# Altium schematic sheet driver.SchDoc
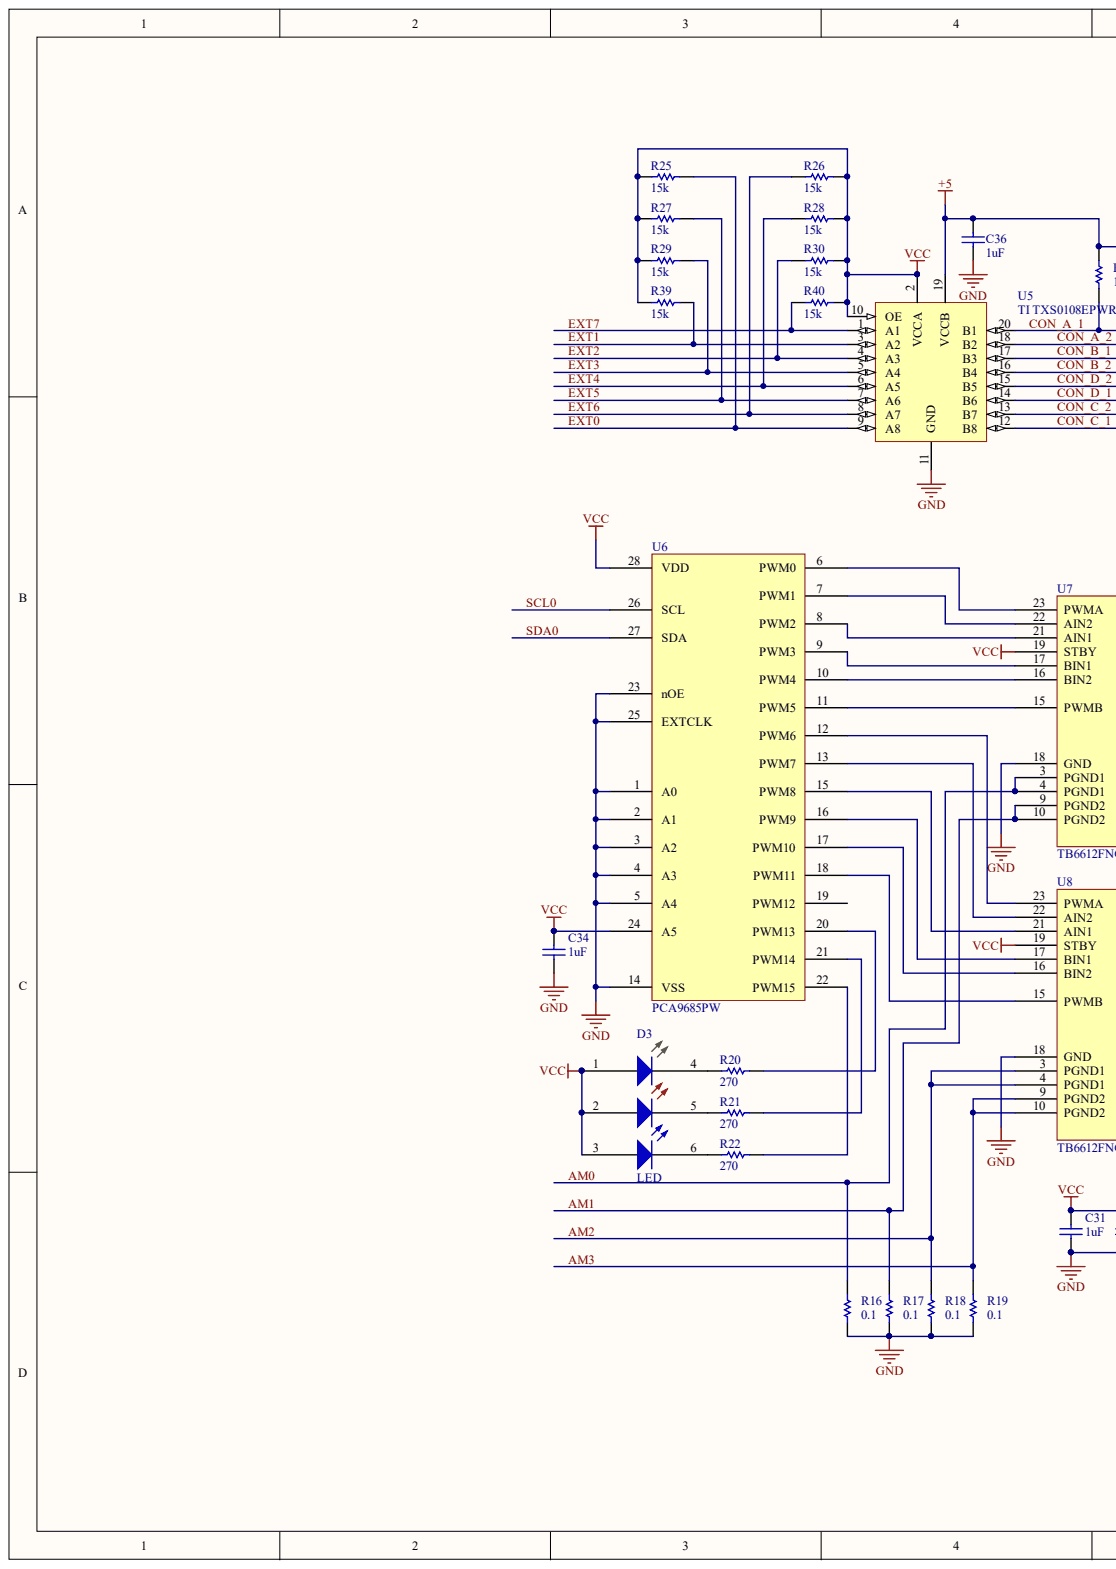
[diagram p0: driver.SchDoc, left]
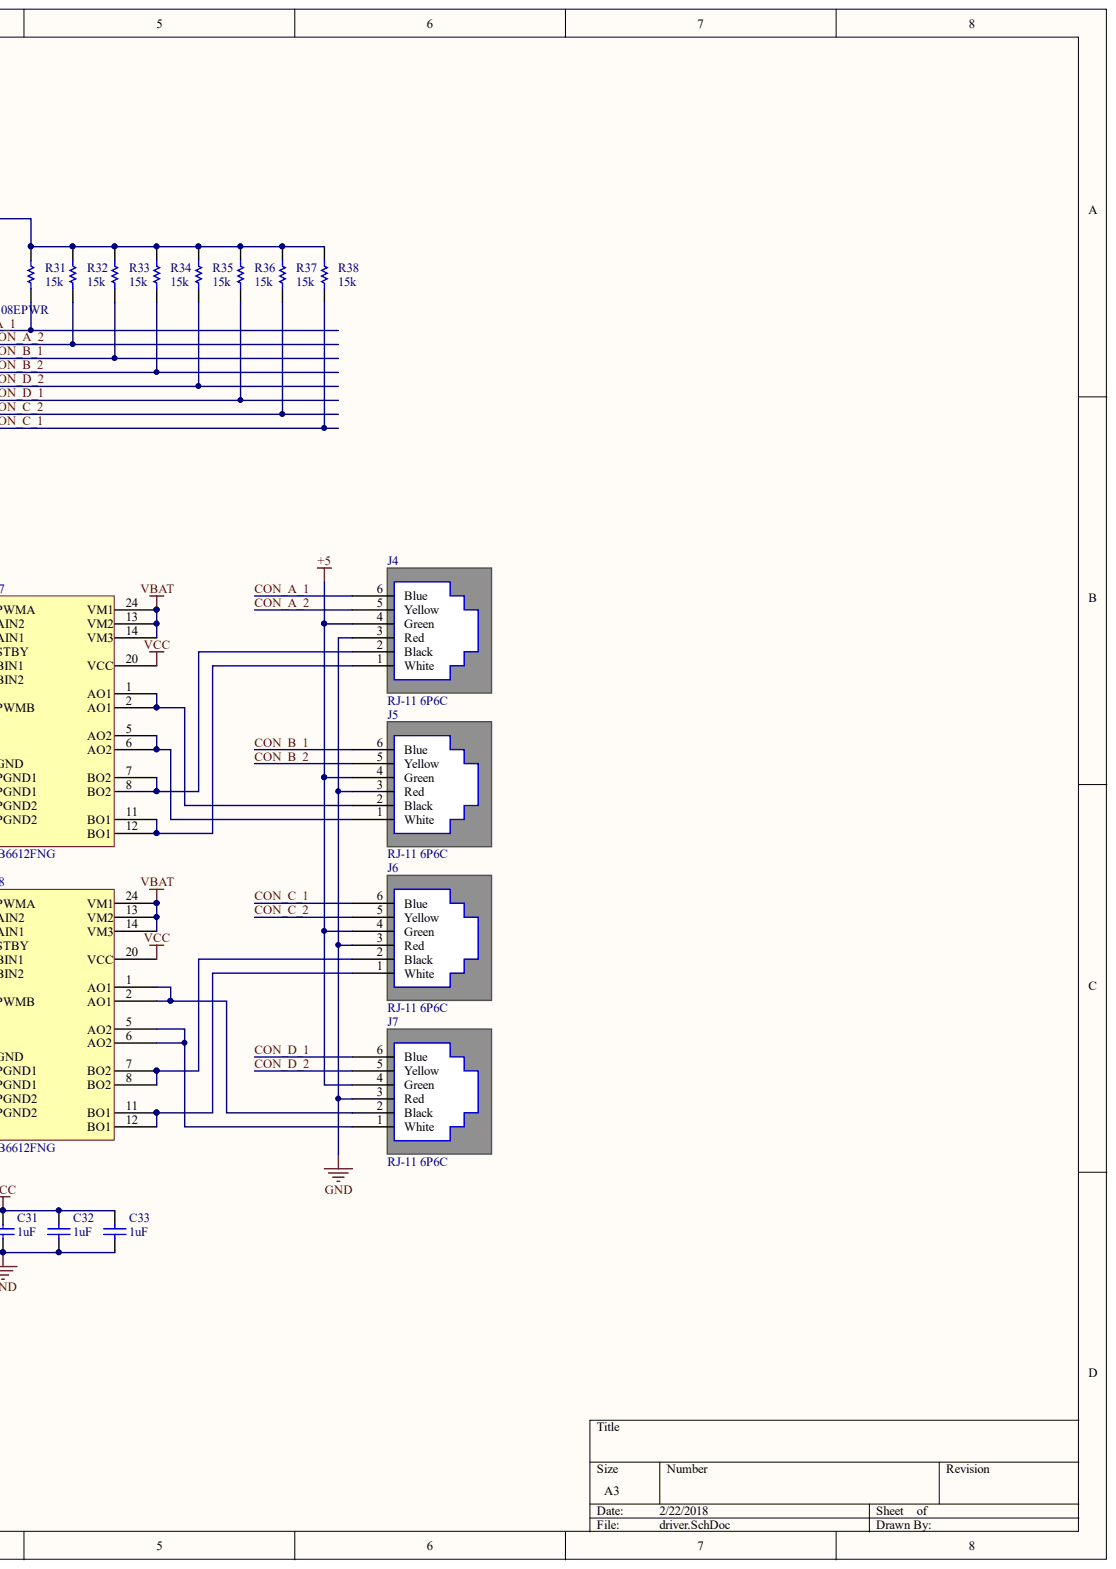
[diagram p1: driver.SchDoc, right]

## Parts
C31  Capacitance: 1uF  [CAPC1608X90X35LL15T15]  (hidden comment: AVX Interconnect / Elco 06036D105KAT2A)
C32  Capacitance: 1uF  [CAPC1608X90X35LL15T15]  (hidden comment: AVX Interconnect / Elco 06036D105KAT2A)
C33  Capacitance: 1uF  [CAPC1608X90X35LL15T15]  (hidden comment: AVX Interconnect / Elco 06036D105KAT2A)
C34  Capacitance: 1uF  [CAPC1608X90X35LL15T15]  (hidden comment: AVX Interconnect / Elco 06036D105KAT2A)
C36  Capacitance: 1uF  [CAPC1608X90X35LL15T15]  (hidden comment: AVX Interconnect / Elco 06036D105KAT2A)
D3  LED  [PCB-b482zloe7qu4nyfcg9uy-1]
J4  RJ-11 6P6C  [PCB-dhj0y0hoiu0232y63q4o-1]
J5  RJ-11 6P6C  [PCB-dhj0y0hoiu0232y63q4o-1]
J6  RJ-11 6P6C  [PCB-dhj0y0hoiu0232y63q4o-1]
J7  RJ-11 6P6C  [PCB-dhj0y0hoiu0232y63q4o-1]
R16  Resistance: 0.1  [PCB-7w67gjgbmz27625n7m9y-1]  (hidden comment: Panasonic ERJ-S6SFR10V)
R17  Resistance: 0.1  [PCB-7w67gjgbmz27625n7m9y-1]  (hidden comment: Panasonic ERJ-S6SFR10V)
R18  Resistance: 0.1  [PCB-7w67gjgbmz27625n7m9y-1]  (hidden comment: Panasonic ERJ-S6SFR10V)
R19  Resistance: 0.1  [PCB-7w67gjgbmz27625n7m9y-1]  (hidden comment: Panasonic ERJ-S6SFR10V)
R20  Vishay Dale CRCW0603200KFKEA  [RESC1609X50X30ML10T20]  (value not drawn)
R21  Vishay Dale CRCW0603200KFKEA  [RESC1609X50X30ML10T20]  (value not drawn)
R22  Vishay Dale CRCW0603200KFKEA  [RESC1609X50X30ML10T20]  (value not drawn)
R25  Resistance: 15k  [RESC1608X55X30NL20T20]  (hidden comment: Panasonic ERA-3AED153V)
R26  Resistance: 15k  [RESC1608X55X30NL20T20]  (hidden comment: Panasonic ERA-3AED153V)
R27  Resistance: 15k  [RESC1608X55X30NL20T20]  (hidden comment: Panasonic ERA-3AED153V)
R28  Resistance: 15k  [RESC1608X55X30NL20T20]  (hidden comment: Panasonic ERA-3AED153V)
R29  Resistance: 15k  [RESC1608X55X30NL20T20]  (hidden comment: Panasonic ERA-3AED153V)
R30  Resistance: 15k  [RESC1608X55X30NL20T20]  (hidden comment: Panasonic ERA-3AED153V)
R31  Resistance: 15k  [RESC1608X55X30NL20T20]  (hidden comment: Panasonic ERA-3AED153V)
R32  Resistance: 15k  [RESC1608X55X30NL20T20]  (hidden comment: Panasonic ERA-3AED153V)
R33  Resistance: 15k  [RESC1608X55X30NL20T20]  (hidden comment: Panasonic ERA-3AED153V)
R34  Resistance: 15k  [RESC1608X55X30NL20T20]  (hidden comment: Panasonic ERA-3AED153V)
R35  Resistance: 15k  [RESC1608X55X30NL20T20]  (hidden comment: Panasonic ERA-3AED153V)
R36  Resistance: 15k  [RESC1608X55X30NL20T20]  (hidden comment: Panasonic ERA-3AED153V)
R37  Resistance: 15k  [RESC1608X55X30NL20T20]  (hidden comment: Panasonic ERA-3AED153V)
R38  Resistance: 15k  [RESC1608X55X30NL20T20]  (hidden comment: Panasonic ERA-3AED153V)
R39  Resistance: 15k  [RESC1608X55X30NL20T20]  (hidden comment: Panasonic ERA-3AED153V)
R40  Resistance: 15k  [RESC1608X55X30NL20T20]  (hidden comment: Panasonic ERA-3AED153V)
U5  TI TXS0108EPWR  Manufacturer Part Number: TXS0108EPWR  [TSSOP20-0.65-6.5X4.4MM]
U6  PCA9685PW  [SOP28]
U7  TB6612FNG  [PCB-j6inoi7yxibl7naj9frb-1]
U8  TB6612FNG  [PCB-j6inoi7yxibl7naj9frb-1]

## Nets
+5: C36.1 J4.4(Green) J5.4(Green) J6.4(Green) J7.4(Green) R31.2 R32.2 R33.2 R34.2 R35.2 R36.2 R37.2 R38.2 U5.19(VCCB)
GND: C31.1 C32.1 C33.1 C34.1 C36.2 J4.3(Red) J5.3(Red) J6.3(Red) J7.3(Red) R16.1 R17.1 R18.1 R19.1 U5.11(GND) U6.1(A0) U6.2(A1) U6.3(A2) U6.4(A3) U6.5(A4) U6.14(VSS) U6.23(nOE) U6.25(EXTCLK) U7.18(GND) U8.18(GND)
VBAT: U7.13(VM2) U7.14(VM3) U7.24(VM1) U8.13(VM2) U8.14(VM3) U8.24(VM1)
VCC: C31.2 C32.2 C33.2 C34.2 D3.1 D3.2 D3.3 R25.2 R26.1 R27.2 R28.1 R29.2 R30.1 R39.2 R40.1 U5.2(VCCA) U5.10(OE) U6.24(A5) U6.28(VDD) U7.19(STBY) U7.20(VCC) U8.19(STBY) U8.20(VCC)
AM0: R16.2 U7.3(PGND1) U7.4(PGND1)
AM1: R17.2 U7.9(PGND2) U7.10(PGND2)
AM2: R18.2 U8.3(PGND1) U8.4(PGND1)
AM3: R19.2 U8.9(PGND2) U8.10(PGND2)
CON_A_1: J4.6(Blue) R31.1 U5.20(B1)
CON_A_2: J4.5(Yellow) R32.1 U5.18(B2)
CON_B_1: J5.6(Blue) R33.1 U5.17(B3)
CON_B_2: J5.5(Yellow) R34.1 U5.16(B4)
CON_C_1: J6.6(Blue) R38.1 U5.12(B8)
CON_C_2: J6.5(Yellow) R37.1 U5.13(B7)
CON_D_1: J7.6(Blue) R36.1 U5.14(B6)
CON_D_2: J7.5(Yellow) R35.1 U5.15(B5)
EXT0: R25.1 U5.9(A8)
EXT1: R39.1 U5.3(A2)
EXT2: R30.2 U5.4(A3)
EXT3: R29.1 U5.5(A4)
EXT4: R28.2 U5.6(A5)
EXT5: R27.1 U5.7(A6)
EXT6: R26.2 U5.8(A7)
EXT7: R40.2 U5.1(A1)
SCL0: U6.26(SCL)
SDA0: U6.27(SDA)
NetD3_4: D3.4 R20.1
NetD3_5: D3.5 R21.1
NetD3_6: D3.6 R22.1
NetJ4_1: J4.1(White) U7.11(BO1) U7.12(BO1)
NetJ4_2: J4.2(Black) U7.7(BO2) U7.8(BO2)
NetJ5_1: J5.1(White) U7.5(AO2) U7.6(AO2)
NetJ5_2: J5.2(Black) U7.1(AO1) U7.2(AO1)
NetJ6_1: J6.1(White) U8.11(BO1) U8.12(BO1)
NetJ6_2: J6.2(Black) U8.7(BO2) U8.8(BO2)
NetJ7_1: J7.1(White) U8.5(AO2) U8.6(AO2)
NetJ7_2: J7.2(Black) U8.1(AO1) U8.2(AO1)
NetR20_2: R20.2 U6.20(PWM13)
NetR21_2: R21.2 U6.21(PWM14)
NetR22_2: R22.2 U6.22(PWM15)
NetU6_6: U6.6(PWM0) U7.23(PWMA)
NetU6_7: U6.7(PWM1) U7.22(AIN2)
NetU6_8: U6.8(PWM2) U7.21(AIN1)
NetU6_9: U6.9(PWM3) U7.17(BIN1)
NetU6_10: U6.10(PWM4) U7.16(BIN2)
NetU6_11: U6.11(PWM5) U7.15(PWMB)
NetU6_12: U6.12(PWM6) U8.23(PWMA)
NetU6_13: U6.13(PWM7) U8.22(AIN2)
NetU6_15: U6.15(PWM8) U8.21(AIN1)
NetU6_16: U6.16(PWM9) U8.17(BIN1)
NetU6_17: U6.17(PWM10) U8.16(BIN2)
NetU6_18: U6.18(PWM11) U8.15(PWMB)

Not connected: U6 19(PWM12)

## Library data of the parts
C31-C34 C36 = CMP-126022-2: CAP CER 1UF 6.3V 10% X5R 0603; Dielectric Material: Ceramic Multilayer; Insulation Resistance: 1e+11 Ω; Lead-Free Status: Lead Free; Capacitance Tolerance: ±10%; Case/Package: 0603; Component Kind: Standard; Dielectric Characteristic: X5R; Library Name: CommunityVault; Mounting Style: Surface Mount; Number of Pins: 2; OctopartID: 12fddbf79c04cd3a; Octopart Url: https://octopart.com/06036d105kat2a-avx+interconnect+%2F+elco-43935; REACH SVHC Compliance: No SVHC; RoHS: Compliant; Size-Height: 0.00086 m; Size-Length: 0.0016 m; Size-Thickness: 0.0008636 m; Size-Width: 0.00081 m; Voltage Rating (DC): 6.3 V
D3 = CMP-c91e00dca3f716d9-6: LED, SMD, PLCC6, Red, Green, Blue, 650/1000/400 mcd TYP, 120 View Angle; Manufacturer Part Number: SMP6-RGB; Lead-Free Status: Lead Free; Viewing Angle: 120; Component Kind: Standard; Library Name: CommunityVault; Number of Pins: 6; RoHS: Compliant
J4-J7 = CMP-33ecfa0a2b0dec2b-2: Conn RJ-11 F 6 POS 1.02mm Solder RA Thru-Hole 6 Terminal 1 Port; Manufacturer Part Number: PT-J623SS-66GR; Component Kind: Standard; Library Name: CommunityVault; Lifecycle Status: Not Listed by Manufacturer; OctopartID: 33ecfa0a2b0dec2b; RoHS: Compliant
R16-R19 = CMP-1d26d515c31ec2e1-1: SMD Current Sense Resistors, AEC-Q200 ERJ Series, 0.1 ohm, 250 mW, 1%, 0805 [2012 Metric]; Power Rating: 250 mW; Case/Package: 0805, 2012; Component Kind: Standard; Library Name: CommunityVault; Lifecycle Status: Not Listed by Manufacturer; Manufacturer Part Number: ERJ-S6SFR10V; OctopartID: 1d26d515c31ec2e1; Octopart URL: http://octopart.com/erj-s6sfr10v-panasonic-20577118; Packaging: Cut Tape (CT); REACH SVHC Compliance: No SVHC; Resistance Tolerance: ±1%; RoHS: Compliant
R20-R22 = CMP-1857245-4: RES SMD 200K OHM 1% 1/10W 0603; Lead-Free Status: Lead Free; Power Rating: 0.1 W; Resistance: 270; Temperature Coefficient: 100.0 ppm/°C; Voltage Rating (DC): 75.0 V; Case/Package: 0603; Component Kind: Standard; Library Name: CommunityVault; Mounting Style: Surface Mount; Number of Pins: 2; OctopartID: 353afe6f23fdeafd; Octopart Url: https://octopart.com/crcw0603200kfkea-vishay-39793569; REACH SVHC Compliance: No SVHC; Resistance Tolerance: ±1%; RoHS: Compliant; Size-Height: 0.00045 m; Size-Length: 0.00155 m; Size-Width: 0.00085 m
R25-R40 = CMP-4023581-2: PANASONIC   ERA-3AED153V   THIN FILM, RESISTOR, 15KOHM, 100mW, 0.5%; Power Rating: 0.1 W; Voltage Rating (DC): 75.0 V; Component Kind: Standard; Library Name: CommunityVault; Lifecycle Status: Not Listed by Manufacturer; OctopartID: 54079c681bee8bc0; Octopart Url: https://octopart.com/era-3aed153v-panasonic-23423631; Packaging: Cut Tape (CT); Resistance Tolerance: ±0.5%; RoHS: Compliant
U5 = CMP-677dae1bd4ffb8ba-2: 8-Bit Bidirectional Voltage-Level Shifter For Open-Drain And Push-Pull Application 20-TSSOP -40 to 85; Data Rate: 60000000.0 bps; Lead-Free Status: Lead Free; Number of Bits: 8; Output Current: 620.0 A; Rise Time: 6.3e-09 s; Supply Current: 8e-06 A; Case/Package: TSSOP; Component Kind: Standard; Library Name: CommunityVault; Lifecycle Status: Active; Mounting Style: Surface Mount; Number of Pins: 20; OctopartID: 677dae1bd4ffb8ba; Octopart Url: http://octopart.com/txs0108epwr-texas+instruments-7116516; REACH SVHC Compliance: No SVHC; RoHS: Compliant; Seller Landing  Url: https://octopart-clicks.com/click/track?country=US&ak=8157f166&sig=0eb2c82&sid=5489&ppid=7116516&vpid=47661640&ct=offers
U6 = CMP-d7fd1e432ce0083a-4: PCA9685 Series 5.5V 25 mA 1 MHz 12-bit PWM Fm+I2C-Bus LED Controller - TSSOP-28; Manufacturer Part Number: PCA9685PW,118; Component Kind: Standard; Library Name: CommunityVault; Mounting Style: Surface Mount; Packaging: Tape & Reel (TR); RoHS: Compliant
U7 U8 = CMP-19a5d1d8b246c662-4: Stepping Motor Driver Ic Unipolor Copper Wire 8"; Manufacturer Part Number: TB6612FNG(O,C,8,EL; Component Kind: Standard; Library Name: CommunityVault; OctopartID: 19a5d1d8b246c662; Octopart URL: http://octopart.com/tb6612fng%28o%2Cc%2C8%2Cel-toshiba-24612485
